# Altium schematic sheet RP2040.SchDoc(RP2040)
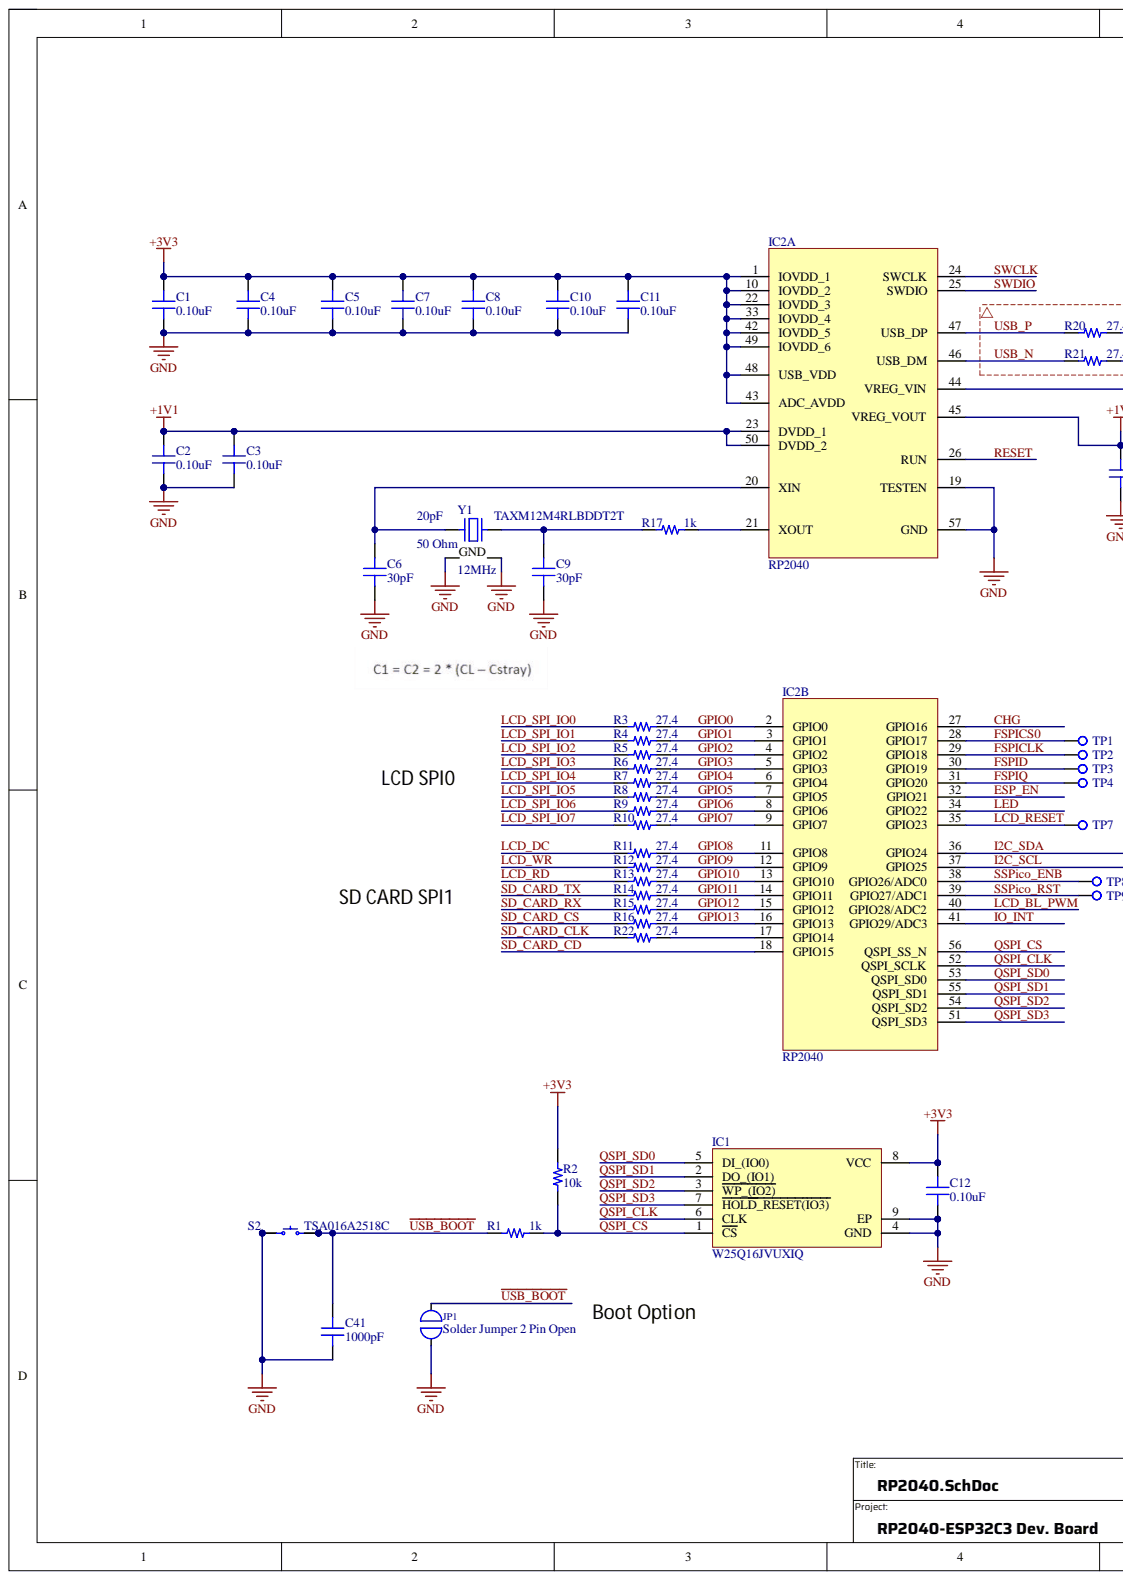
[diagram p0: RP2040.SchDoc, left]
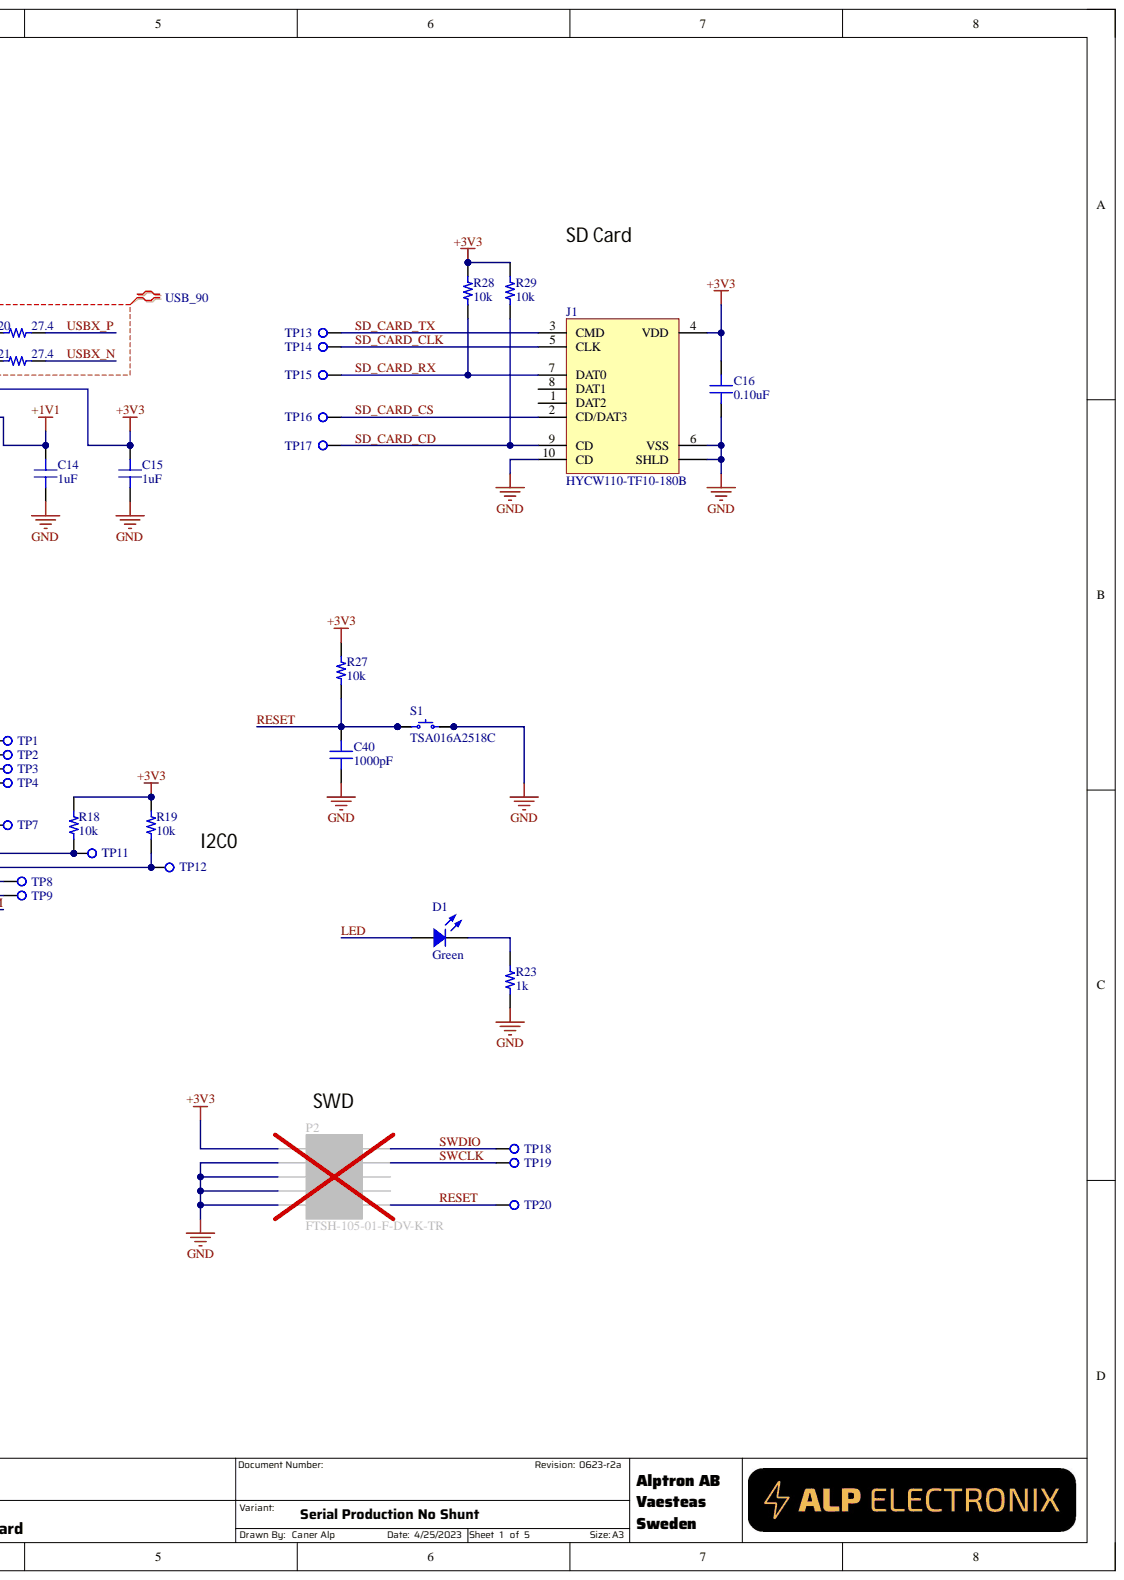
[diagram p1: RP2040.SchDoc, right]

## Parts
C1  0.10uF  [CAP 0402/1005]
C2  0.10uF  [CAP 0402/1005]
C3  0.10uF  [CAP 0402/1005]
C4  0.10uF  [CAP 0402/1005]
C5  0.10uF  [CAP 0402/1005]
C6  30pF  [CAP 0402/1005]
C7  0.10uF  [CAP 0402/1005]
C8  0.10uF  [CAP 0402/1005]
C9  30pF  [CAP 0402/1005]
C10  0.10uF  [CAP 0402/1005]
C11  0.10uF  [CAP 0402/1005]
C12  0.10uF  [CAP 0402/1005]
C14  1uF  [CAP 0402/1005]
C15  1uF  [CAP 0402/1005]
C16  0.10uF  [CAP 0402/1005]
C40  1000pF  [CAP 0402/1005]
C41  1000pF  [CAP 0402/1005]
D1  Green  [LED 0603/1608 GREEN]
IC1  W25Q16JVUXIQ  [SON50P300X200X60-9N]
IC2  RP2040  [QFN40P700X700X90-57N-D]  (parts A,B)
J1  HYCW110-TF10-180B  [HYCW110-TF10-180B]
JP1  Solder Jumper 2 Pin Open
P2  FTSH-105-01-F-DV-K-TR  [FTSH-105-01-F-DV-K-TR]
R1  1k  [RES 0402/1005]
R2  10k  [RES 0402/1005]
R3  27.4  [RES 0402/1005]
R4  27.4  [RES 0402/1005]
R5  27.4  [RES 0402/1005]
R6  27.4  [RES 0402/1005]
R7  27.4  [RES 0402/1005]
R8  27.4  [RES 0402/1005]
R9  27.4  [RES 0402/1005]
R10  27.4  [RES 0402/1005]
R11  27.4  [RES 0402/1005]
R12  27.4  [RES 0402/1005]
R13  27.4  [RES 0402/1005]
R14  27.4  [RES 0402/1005]
R15  27.4  [RES 0402/1005]
R16  27.4  [RES 0402/1005]
R17  1k  [RES 0402/1005]
R18  10k  [RES 0402/1005]
R19  10k  [RES 0402/1005]
R20  27.4  [RES 0402/1005]
R21  27.4  [RES 0402/1005]
R22  27.4  [RES 0402/1005]
R23  1k  [RES 0402/1005]
R27  10k  [RES 0402/1005]
R28  10k  [RES 0402/1005]
R29  10k  [RES 0402/1005]
S1  TSA016A2518C  [TSA016A2518C]
S2  TSA016A2518C  [TSA016A2518C]
TP1  RCT-0C  [TestPoint 1mm]  (value not drawn)  (no BOM)
TP2  RCT-0C  [TestPoint 1mm]  (value not drawn)  (no BOM)
TP3  RCT-0C  [TestPoint 1mm]  (value not drawn)  (no BOM)
TP4  RCT-0C  [TestPoint 1mm]  (value not drawn)  (no BOM)
TP7  RCT-0C  [TestPoint 1mm]  (value not drawn)  (no BOM)
TP8  RCT-0C  [TestPoint 1mm]  (value not drawn)  (no BOM)
TP9  RCT-0C  [TestPoint 1mm]  (value not drawn)  (no BOM)
TP11  RCT-0C  [TestPoint 1mm]  (value not drawn)  (no BOM)
TP12  RCT-0C  [TestPoint 1mm]  (value not drawn)  (no BOM)
TP13  RCT-0C  [TestPoint 1mm]  (value not drawn)  (no BOM)
TP14  RCT-0C  [TestPoint 1mm]  (value not drawn)  (no BOM)
TP15  RCT-0C  [TestPoint 1mm]  (value not drawn)  (no BOM)
TP16  RCT-0C  [TestPoint 1mm]  (value not drawn)  (no BOM)
TP17  RCT-0C  [TestPoint 1mm]  (value not drawn)  (no BOM)
TP18  RCT-0C  [TestPoint 1mm]  (value not drawn)  (no BOM)
TP19  RCT-0C  [TestPoint 1mm]  (value not drawn)  (no BOM)
TP20  RCT-0C  [TestPoint 1mm]  (value not drawn)  (no BOM)
Y1  12MHz  ESR: 50 Ohm  Load Capacitance: 20pF  Part Number: TAXM12M4RLBDDT2T  [NDK NX3225SA]

## Nets
+1V1: C2.2 C3.2 C14.2 IC2.23(DVDD_1) IC2.45(VREG_VOUT) IC2.50(DVDD_2)
+3V3: C1.2 C4.2 C5.2 C7.2 C8.2 C10.2 C11.2 C12.2 C15.2 C16.2 IC1.8(VCC) IC2.1(IOVDD_1) IC2.10(IOVDD_2) IC2.22(IOVDD_3) IC2.33(IOVDD_4) IC2.42(IOVDD_5) IC2.43(ADC_AVDD) IC2.44(VREG_VIN) IC2.48(USB_VDD) IC2.49(IOVDD_6) J1.4(VDD) P2.1 R2.2 R18.2 R19.2 R27.2 R28.2 R29.2
GND: C1.1 C2.1 C3.1 C4.1 C5.1 C6.1 C7.1 C8.1 C9.1 C10.1 C11.1 C12.1 C14.1 C15.1 C16.1 C40.1 C41.1 IC1.4(GND) IC1.9(EP) IC2.19(TESTEN) IC2.57(GND) J1.6(VSS) J1.10(CD) J1.S(SHLD) JP1.1 P2.3 P2.5 P2.7 P2.9 R23.1 S1.3 S1.4 S2.1 S2.2 Y1.2 Y1.4(GND)
~{USB_BOOT}: C41.2 JP1.2 R1.1 S2.3 S2.4
CHG: IC2.27(GPIO16)
ESP_EN: IC2.32(GPIO21)
FSPICLK: IC2.29(GPIO18) TP2.1
FSPICS0: IC2.28(GPIO17) TP1.1
FSPID: IC2.30(GPIO19) TP3.1
FSPIQ: IC2.31(GPIO20) TP4.1
GPIO0: IC2.2(GPIO0) R3.2
GPIO1: IC2.3(GPIO1) R4.2
GPIO2: IC2.4(GPIO2) R5.2
GPIO3: IC2.5(GPIO3) R6.2
GPIO4: IC2.6(GPIO4) R7.2
GPIO5: IC2.7(GPIO5) R8.2
GPIO6: IC2.8(GPIO6) R9.2
GPIO7: IC2.9(GPIO7) R10.2
GPIO8: IC2.11(GPIO8) R11.2
GPIO9: IC2.12(GPIO9) R12.2
GPIO10: IC2.13(GPIO10) R13.2
GPIO11: IC2.14(GPIO11) R14.2
GPIO12: IC2.15(GPIO12) R15.2
GPIO13: IC2.16(GPIO13) R16.2
I2C_SCL: IC2.37(GPIO25) R19.1 TP12.1
I2C_SDA: IC2.36(GPIO24) R18.1 TP11.1
IO_INT: IC2.41(GPIO29/ADC3)
LCD_BL_PWM: IC2.40(GPIO28/ADC2)
LCD_DC: R11.1
LCD_RD: R13.1
LCD_RESET: IC2.35(GPIO23) TP7.1
LCD_SPI_IO0: R3.1
LCD_SPI_IO1: R4.1
LCD_SPI_IO2: R5.1
LCD_SPI_IO3: R6.1
LCD_SPI_IO4: R7.1
LCD_SPI_IO5: R8.1
LCD_SPI_IO6: R9.1
LCD_SPI_IO7: R10.1
LCD_WR: R12.1
LED: D1.1 IC2.34(GPIO22)
QSPI_CLK: IC1.6(CLK) IC2.52(QSPI_SCLK)
QSPI_CS: IC1.1(CS) IC2.56(QSPI_SS_N) R1.2 R2.1
QSPI_SD0: IC1.5(DI_(IO0)) IC2.53(QSPI_SD0)
QSPI_SD1: IC1.2(DO_(IO1)) IC2.55(QSPI_SD1)
QSPI_SD2: IC1.3(WP_(IO2)) IC2.54(QSPI_SD2)
QSPI_SD3: IC1.7(HOLD_RESET(IO3)) IC2.51(QSPI_SD3)
RESET: C40.2 IC2.26(RUN) P2.10 R27.1 S1.1 S1.2 TP20.1
SD_CARD_CD: IC2.18(GPIO15) J1.9(CD) R29.1 TP17.1
SD_CARD_CLK: J1.5(CLK) R22.1 TP14.1
SD_CARD_CS: J1.2(CD/DAT3) R16.1 TP16.1
SD_CARD_RX: J1.7(DAT0) R15.1 R28.1 TP15.1
SD_CARD_TX: J1.3(CMD) R14.1 TP13.1
SSPico_ENB: IC2.38(GPIO26/ADC0) TP8.1
SSPico_RST: IC2.39(GPIO27/ADC1) TP9.1
SWCLK: IC2.24(SWCLK) P2.4 TP19.1
SWDIO: IC2.25(SWDIO) P2.2 TP18.1
USB_N: IC2.46(USB_DM) R21.1
USB_P: IC2.47(USB_DP) R20.1
USBX_N: R21.2
USBX_P: R20.2
NetC6_2: C6.2 IC2.20(XIN) Y1.1
NetC9_2: C9.2 R17.1 Y1.3
NetD1_2: D1.2 R23.2
NetIC2_17: IC2.17(GPIO14) R22.2
NetIC2_21: IC2.21(XOUT) R17.2

Not connected: J1 1(DAT2) 8(DAT1); P2 6 8

## Library data of the parts
C1-C5 C7 C8 C10-C12 C16 = LMK105BJ104KV-F: CAP CER 0.1UF 10V X5R 0402; Manufacturer: Taiyo Yuden; Database Table Name: Capacitor - Ceramic; TemperatureRange: -55C ~ 85C; Tolerance: 10%; Component Kind: Standard; ComponentLink1Description: Datasheet; ComponentLink1URL: http://ds.yuden.co.jp/TYCOMPAS/ut/detail.do?productNo=LMK105BJ104KV-F&fileName=LMK105BJ104KV-F_SS&mode=specSheetDownload; ComponentLink2Description: Digi-Key Listing; ComponentLink2URL: https://www.digikey.com/product-detail/en/taiyo-yuden/LMK105BJ104KV-F/587-1227-2-ND/930579; Device Package: 0402 (1005 Metric); Library Name: Celestial Library Database.DbLib; Part Number: LMK105BJ104KV-F; PartId: 12322; Price: 0,0021; Supplier 1: Digi-Key; Supplier Part Number 1: 587-1227-2-ND; TemperatureCoefficient: X5R; Value: 0.10uF; Voltage: 10V
C6 C9 = GRM1555C1H300JA01D: CAP CER 30PF 50V NP0 0402; Manufacturer: Murata Electronics North America; Database Table Name: Capacitor - Ceramic; TemperatureCoefficient: C0G, NP0; TemperatureRange: -55C ~ 125C; Tolerance: 5%; Component Kind: Standard; ComponentLink1Description: Datasheet; ComponentLink1URL: http://www.murata.com/~/media/webrenewal/support/library/catalog/products/capacitor/mlcc/c02e.ashx; ComponentLink2Description: Digi-Key listing; ComponentLink2URL: http://digikey.com/product-detail/en/GRM1555C1H300JA01D/490-5935-2-ND/3693845; Device Package: 0402 (1005 Metric); Library Name: Celestial Library Database.DbLib; Part Number: GRM1555C1H300JA01D; PartId: 5055; Price: 0,0118; Supplier 1: Digi-Key; Supplier Part Number 1: 490-5935-2-ND; Type: -; Value: 30pF; Voltage: 50V
C14 C15 = GRM155R70J105KA12D: CAP CER 1UF 6.3V X7R 0402; Manufacturer: Murata Electronics North America; Database Table Name: Capacitor - Ceramic; TemperatureRange: -55C ~ 125C; Tolerance: 10%; Type: Derating Recommended; Component Kind: Standard; ComponentLink1Description: Datasheet; ComponentLink1URL: http://www.murata.com/~/media/webrenewal/support/library/catalog/products/capacitor/mlcc/c02e.ashx; ComponentLink2Description: Digi-Key listing; ComponentLink2URL: http://digikey.com/product-detail/en/GRM155R70J105KA12D/490-10024-2-ND/5027109; Device Package: 0402 (1005 Metric); Library Name: Celestial Library Database.DbLib; Part Number: GRM155R70J105KA12D; PartId: 5157; Price: 0,019; Supplier 1: Digi-Key; Supplier Part Number 1: 490-10024-2-ND; TemperatureCoefficient: X7R; Value: 1uF; Voltage: 6.3V
C40 C41 = C1005C0G1H102J050BA: CAP CER 1000PF 50V C0G 0402; Manufacturer: TDK Corporation; Database Table Name: Capacitor - Ceramic; TemperatureCoefficient: C0G, NP0; TemperatureRange: -55C ~ 125C; Tolerance: 5%; Component Kind: Standard; ComponentLink1Description: Datasheet; ComponentLink1URL: https://product.tdk.com/info/en/catalog/datasheets/mlcc_commercial_general_en.pdf; ComponentLink2Description: Digi-Key listing; ComponentLink2URL: http://digikey.com/product-detail/en/C1005C0G1H102J050BA/445-6846-2-ND/2619187; Device Package: 0402 (1005 Metric); Library Name: Celestial Library Database.DbLib; Part Number: C1005C0G1H102J050BA; PartId: 3861; Price: 0,0287; Supplier 1: Digi-Key; Supplier Part Number 1: 445-6846-2-ND; Type: -; Value: 1000pF; Voltage: 50V
D1 = HSMQ-C191: LED GREEN DIFFUSED 0603 SMD; Manufacturer: Avago Technologies US Inc.; Current (Test): 20mA; Database Table Name: Chip LED; Millicandela: 145mcd; Type: Surface Mount; Viewing Angle: 140; Voltage Forward: 3.4V; Colour: Green; Component Kind: Standard; ComponentLink1Description: Datasheet; ComponentLink1URL: http://www.avagotech.com/docs/AV02-0977EN; ComponentLink2Description: Digi-Key Listing; ComponentLink2URL: http://www.digikey.ca/product-detail/en/HSMQ-C191/516-1807-2-ND/1012398; Device Package: 0603 (1608 Metric); Lens Transparency: Diffused; Library Name: Celestial Library Database.DbLib; Part Number: HSMQ-C191; PartId: 7059; Price: 0,4769; Supplier 1: Digi-Key; Supplier Part Number 1: 516-1807-2-ND; Value: Green
IC1 = W25Q16JVUXIQ: Integrated Circuit; Manufacturer_Name: Winbond; Component Kind: Standard; Datasheet Link: https://www.winbond.com/resource-files/w25q16jv%20spi%20revh%2004082019%20plus.pdf; Height: 0.6mm; Library Name: RP2040_ESP32-C3 Dev Board SCH Lib.SchLib; Manufacturer_Part_Number: W25Q16JVUXIQ
IC2 = RP2040: Integrated Circuit; Manufacturer_Name: RASPBERRY-PI; Component Kind: Standard; Datasheet Link: https://datasheets.raspberrypi.org/rp2040/rp2040-datasheet.pdf; Height: 0.9mm; Library Name: RP2040_ESP32-C3 Dev Board SCH Lib.SchLib; Manufacturer_Part_Number: RP2040
J1 = HYCW110-TF10-180B: MICRO SD PUSH/PUSH SMALL 8C; Manufacturer: SHOU HAN; Connector Type: Connector and Ejector; Number of Positions: 9(8 + 1); Component Kind: Standard; ComponentLink1Description: Datasheet; ComponentLink1URL: https://datasheet.lcsc.com/szlcsc/1912111437_SHOU-HAN-TF-PUSH_C393941.pdf; ComponentLink2Description: LCSC Listing; ComponentLink2URL: https://lcsc.com/product-detail/Card-Sockets-Connectors_SHOU-HAN-TF-PUSH_C393941.html; Contact Finish: Nickel; Features: Switch; Library Name: RP2040_ESP32-C3 Dev Board SCH Lib.SchLib; Mounting Type: Surface Mount, Right Angle; Part Number: HYCW110-TF10-180B; PartId: 9290; Supplier 1: LCSC; Supplier Part Number 1: C393941; Type: Connector and Ejector
JP1 = SolderJumper_2_Open: ki_description: Solder Jumper, 2-pole, open; ki_fp_filters: SolderJumper*Open*; ki_keywords: solder jumper SPST; Component Kind: Net Tie; Library Name: Jumper.SchLib; Supplier: ~
P2 = Header 5X2: Header, 5-Pin, Dual row; Component Kind: Standard; LatestRevisionDate: 17-Jul-2002; LatestRevisionNote: Re-released for DXP Platform.; Library Name: Miscellaneous Connectors.IntLib; Signal Integrity: Connector; Publisher: Altium Limited
R1 R17 R23 = ERJ-2GEJ102X: RES SMD 1K OHM 5% 1/10W 0402; Manufacturer: Panasonic Electronic Components; Database Table Name: Resistor - Chip; PowerRating: 0.1W, 1/10W; TemperatureCoefficient: 200ppm/C; TemperatureRange: -55C ~ 155C; Component Kind: Standard; ComponentLink1Description: Datasheet; ComponentLink1URL: http://industrial.panasonic.com/www-cgi/jvcr13pz.cgi?E+PZ+3+AOA0001+ERJ2GEJ102X+7+WW; ComponentLink2Description: Digi-Key Listing; ComponentLink2URL: https://www.digikey.com/product-detail/en/panasonic-electronic-components/ERJ-2GEJ102X/P1.0KJTR-ND/146898; Device Package: 0402 (1005 Metric); Library Name: Celestial Library Database.DbLib; Part Number: ERJ-2GEJ102X; PartId: 10982; Price: 0,0019; Supplier 1: Digi-Key; Supplier Part Number 1: P1.0KJTR-ND; Tolerance: 5%; Value: 1k
R2 R18 R19 R27-R29 = RC0402JR-0710KL: RES SMD 10K OHM 5% 1/16W 0402; Manufacturer: Yageo; Database Table Name: Resistor - Chip; PowerRating: 0.063W, 1/16W; TemperatureCoefficient: 100ppm/C; TemperatureRange: -55C ~ 155C; Component Kind: Standard; ComponentLink1Description: Datasheet; ComponentLink1URL: http://www.yageo.com/documents/recent/PYu-RC_Group_51_RoHS_L_04.pdf; ComponentLink2Description: Digi-Key Listing; ComponentLink2URL: https://www.digikey.com/product-detail/en/yageo/RC0402JR-0710KL/311-10KJRTR-ND/726418; Device Package: 0402 (1005 Metric); Library Name: Celestial Library Database.DbLib; Part Number: RC0402JR-0710KL; PartId: 14464; Price: 0,0017; Supplier 1: Digi-Key; Supplier Part Number 1: 311-10KJRTR-ND; Tolerance: 5%; Value: 10k
R3-R16 R20-R22 = ERJ-2RKF27R4X: RES SMD 27.4 OHM 1% 1/10W 0402; Manufacturer: Panasonic Electronic Components; Database Table Name: Resistor - Chip; PowerRating: 0.1W, 1/10W; TemperatureCoefficient: 100ppm/C; TemperatureRange: -55C ~ 155C; Component Kind: Standard; ComponentLink1Description: Datasheet; ComponentLink1URL: http://industrial.panasonic.com/www-cgi/jvcr13pz.cgi?E+PZ+3+AOA0002+ERJ2RKF27R4X+7+WW; ComponentLink2Description: Digikey listing; ComponentLink2URL: http://digikey.com/product-detail/en/ERJ-2RKF27R4X/P27.4LTR-ND/192262; Device Package: 0402 (1005 Metric); Library Name: Celestial Library Database.DbLib; Part Number: ERJ-2RKF27R4X; PartId: 342; Price: 0,0043; Supplier 1: Digi-Key; Supplier Part Number 1: P27.4LTR-ND; Tolerance: 1%; Type: Thick Film; Value: 27.4
S1 S2 = PTS810 SJM 250 SMTR LFS: SWITCH TACTILE SPST-NO 0.05A 16V; Manufacturer: C&K; Actuator Height off PCB, Vertical: 2.50mm; Actuator Orientation: Top Actuated; Actuator Type: Oval Button; Contact Rating @ Voltage: 0.05A @ 16VDC; Database Table Name: Switches - Tactile; Illumination: Non-Illuminated; Operating Force: 160gf; Outline: 4.20mm x 3.20mm; Switch Function: Off-Mom; Circuit: SPST-NO; Component Kind: Standard; ComponentLink1Description: Datasheet; ComponentLink1URL: https://dznh3ojzb2azq.cloudfront.net/products/Tactile/PTS810/documents/datasheet.pdf; ComponentLink2Description: DigiKey Link; ComponentLink2URL: http://digikey.com/product-detail/en/c-k/PTS810-SJM-250-SMTR-LFS/CKN10502CT-ND/4176673; LastUpdated: 2020-04-09 10:00:13; Library Name: Celestial Altium Library - altium_library.DbLib; Lifecycle Status: Active; Minimum Order: 1; Mounting Type: Surface Mount; Operating Temperature: -40°C ~ 85°C; Packaging: TapeAndReel; Part Number: TSA016A2518C; Part Status: Active; Price: 0.16; Series: PTS810; Supplier 1: DigiKey; Supplier Part Number 1: CKN10502CT-ND; Termination Style: J Lead
TP1-TP4 TP7-TP9 TP11-TP20 = RCT-0C: 0805 PROBE PAD; Manufacturer: TE Connectivity AMP Connectors; Database Table Name: Test Point; ComponentLink1Description: Datasheet; ComponentLink1URL: http://www.te.com/commerce/DocumentDelivery/DDEController?Action=srchrtrv&DocNm=1773266&DocType=DS&DocLang=English; ComponentLink2Description: Digi-Key Listing; ComponentLink2URL: http://www.digikey.com/product-detail/en/te-connectivity-amp-connectors/RCT-0C/A106144CT-ND/3477801; Library Name: Celestial Library Database.DbLib; Mounting Type: Surface Mount; Part Number: RCT-0C; PartId: 15704; Price: 0,14; Supplier 1: Digi-Key; Supplier Part Number 1: A106144CT-ND; Type: PC Test Point
Y1 = NX3225SA-12.000MHZ-STD-CSR-1: CRYSTAL 12.0000MHZ 8PF SMD; Manufacturer: NDK; Database Table Name: Oscillator - Crystal; Frequency Stability: 15ppm; Operating Mode: Fundamental; Component Kind: Standard; ComponentLink1Description: Datasheet; ComponentLink1URL: http://media.digikey.com/pdf/Data%20Sheets/NDK%20PDFs/NX3225SA.pdf; ComponentLink2Description: Digi-Key Listing; ComponentLink2URL: http://www.digikey.com/product-detail/en/NX3225SA-12.000MHZ-STD-CSR-1/644-1047-2-ND/1128829; Device Package: 4-SMD, No Lead (DFN, LCC); Frequency: 12MHz; Frequency Tolerance: 15ppm; Library Name: Celestial Library Database.DbLib; PartId: 8505; Price: 0,3799; Supplier 1: LCSC; Supplier Part Number 1: 644-1047-2-ND; Type: MHz Crystal
